# Altium schematic sheet cpu.SchDoc
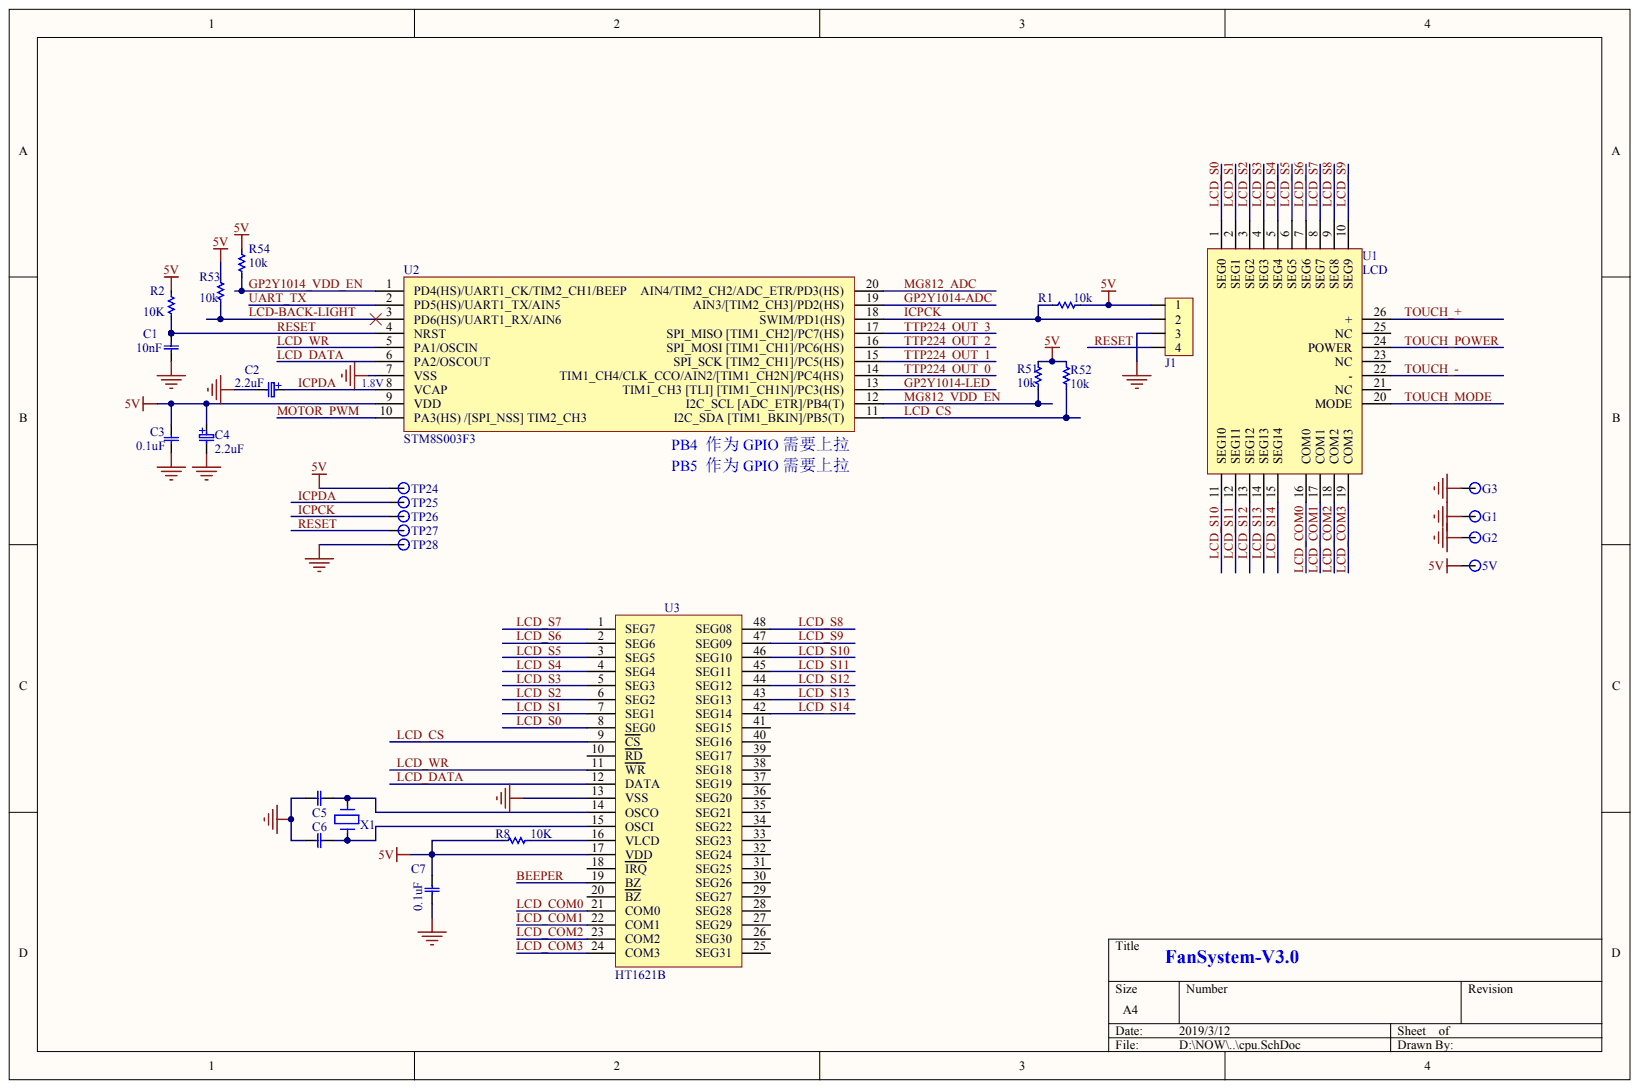
[diagram p0: cpu.SchDoc]

## Parts
5V  TP  (value not drawn)
C1  10nF
C2  2.2uF
C3  0.1uF
C4  2.2uF
C5  5pF  (value not drawn)
C6  5pF  (value not drawn)
C7  0.1uF
G1  TP  (value not drawn)
G2  TP  (value not drawn)
G3  TP  (value not drawn)
J1  CON4  (value not drawn)
R1  10k
R2  10K
R8  10K
R51  10k
R52  10k
R53  10k
R54  10k
TP24  TP
TP25  TP
TP26  TP
TP27  TP
TP28  TP
U1  LCD
U2  STM8S003F3
U3  HT1621B
X1  32.728  (value not drawn)

## Nets
5V: 5V.1 C3.2 C4.A C7.2 J1.1 R1.2 R2.2 R8.1 R51.2 R52.2 R53.2 R54.2 TP24.1 U2.9(VDD) U3.17(VDD)
GND: C1.1 C2.K C3.1 C4.K C5.1 C6.1 C7.1 G1.1 G2.1 G3.1 J1.3 TP28.1 U2.7(VSS) U3.13(VSS)
BEEPER: U3.19(BZ)
GP2Y1014-ADC: U2.19(AIN3/[TIM2_CH3]/PD2(HS))
GP2Y1014-LED: U2.13(TIM1_CH3_[TLI]_[TIM1_CH1N]/PC3(HS))
GP2Y1014_VDD_EN: R54.1 U2.1(PD4(HS)/UART1_CK/TIM2_CH1/BEEP)
ICPCK: J1.2 R1.1 TP26.1 U2.18(SWIM/PD1(HS))
ICPDA: C2.A TP25.1 U2.8(VCAP)
LCD-BACK-LIGHT: R53.1 U2.3(PD6(HS)/UART1_RX/AIN6)
LCD_COM0: U1.16(COM0) U3.21(COM0)
LCD_COM1: U1.17(COM1) U3.22(COM1)
LCD_COM2: U1.18(COM2) U3.23(COM2)
LCD_COM3: U1.19(COM3) U3.24(COM3)
LCD_CS: R52.1 U2.11(I2C_SDA_[TIM1_BKIN]/PB5(T)) U3.9(CS)
LCD_DATA: U2.6(PA2/OSCOUT) U3.12(DATA)
LCD_S0: U1.1(SEG0) U3.8(SEG0)
LCD_S1: U1.2(SEG1) U3.7(SEG1)
LCD_S2: U1.3(SEG2) U3.6(SEG2)
LCD_S3: U1.4(SEG3) U3.5(SEG3)
LCD_S4: U1.5(SEG4) U3.4(SEG4)
LCD_S5: U1.6(SEG5) U3.3(SEG5)
LCD_S6: U1.7(SEG6) U3.2(SEG6)
LCD_S7: U1.8(SEG7) U3.1(SEG7)
LCD_S8: U1.9(SEG8) U3.48(SEG08)
LCD_S9: U1.10(SEG9) U3.47(SEG09)
LCD_S10: U1.11(SEG10) U3.46(SEG10)
LCD_S11: U1.12(SEG11) U3.45(SEG11)
LCD_S12: U1.13(SEG12) U3.44(SEG12)
LCD_S13: U1.14(SEG13) U3.43(SEG13)
LCD_S14: U1.15(SEG14) U3.42(SEG14)
LCD_WR: U2.5(PA1/OSCIN) U3.11(WR)
MG812_ADC: U2.20(AIN4/TIM2_CH2/ADC_ETR/PD3(HS))
MG812_VDD_EN: R51.1 U2.12(I2C_SCL_[ADC_ETR]/PB4(T))
MOTOR_PWM: U2.10(PA3(HS)_/[SPI_NSS]_TIM2_CH3)
RESET: C1.2 J1.4 R2.1 TP27.1 U2.4(NRST)
TOUCH_+: U1.26(+)
TOUCH_-: U1.22(-)
TOUCH_MODE: U1.20(MODE)
TOUCH_POWER: U1.24(POWER)
TTP224_OUT_0: U2.14(TIM1_CH4/CLK_CCO/AIN2/[TIM1_CH2N]/PC4(HS))
TTP224_OUT_1: U2.15(SPI_SCK_[TIM2_CH1]/PC5(HS))
TTP224_OUT_2: U2.16(SPI_MOSI_[TIM1_CH1]/PC6(HS))
TTP224_OUT_3: U2.17(SPI_MISO_[TIM1_CH2]/PC7(HS))
UART_TX: U2.2(PD5(HS)/UART1_TX/AIN5)
NetC5_2: C5.2 U3.14(OSCO) X1.1
NetC6_2: C6.2 U3.15(OSCI) X1.2
NetR8_2: R8.2 U3.16(VLCD)

Not connected: U1 21(NC) 23(NC) 25(NC); U3 10(RD) 18(IRQ) 20(BZ) 25(SEG31) 26(SEG30) 27(SEG29) 28(SEG28) 29(SEG27) 30(SEG26) 31(SEG25) 32(SEG24) 33(SEG23) 34(SEG22) 35(SEG21) 36(SEG20) 37(SEG19) 38(SEG18) 39(SEG17) 40(SEG16) 41(SEG15)
